# Altium schematic sheet Compareter.SchDoc(Compareter)
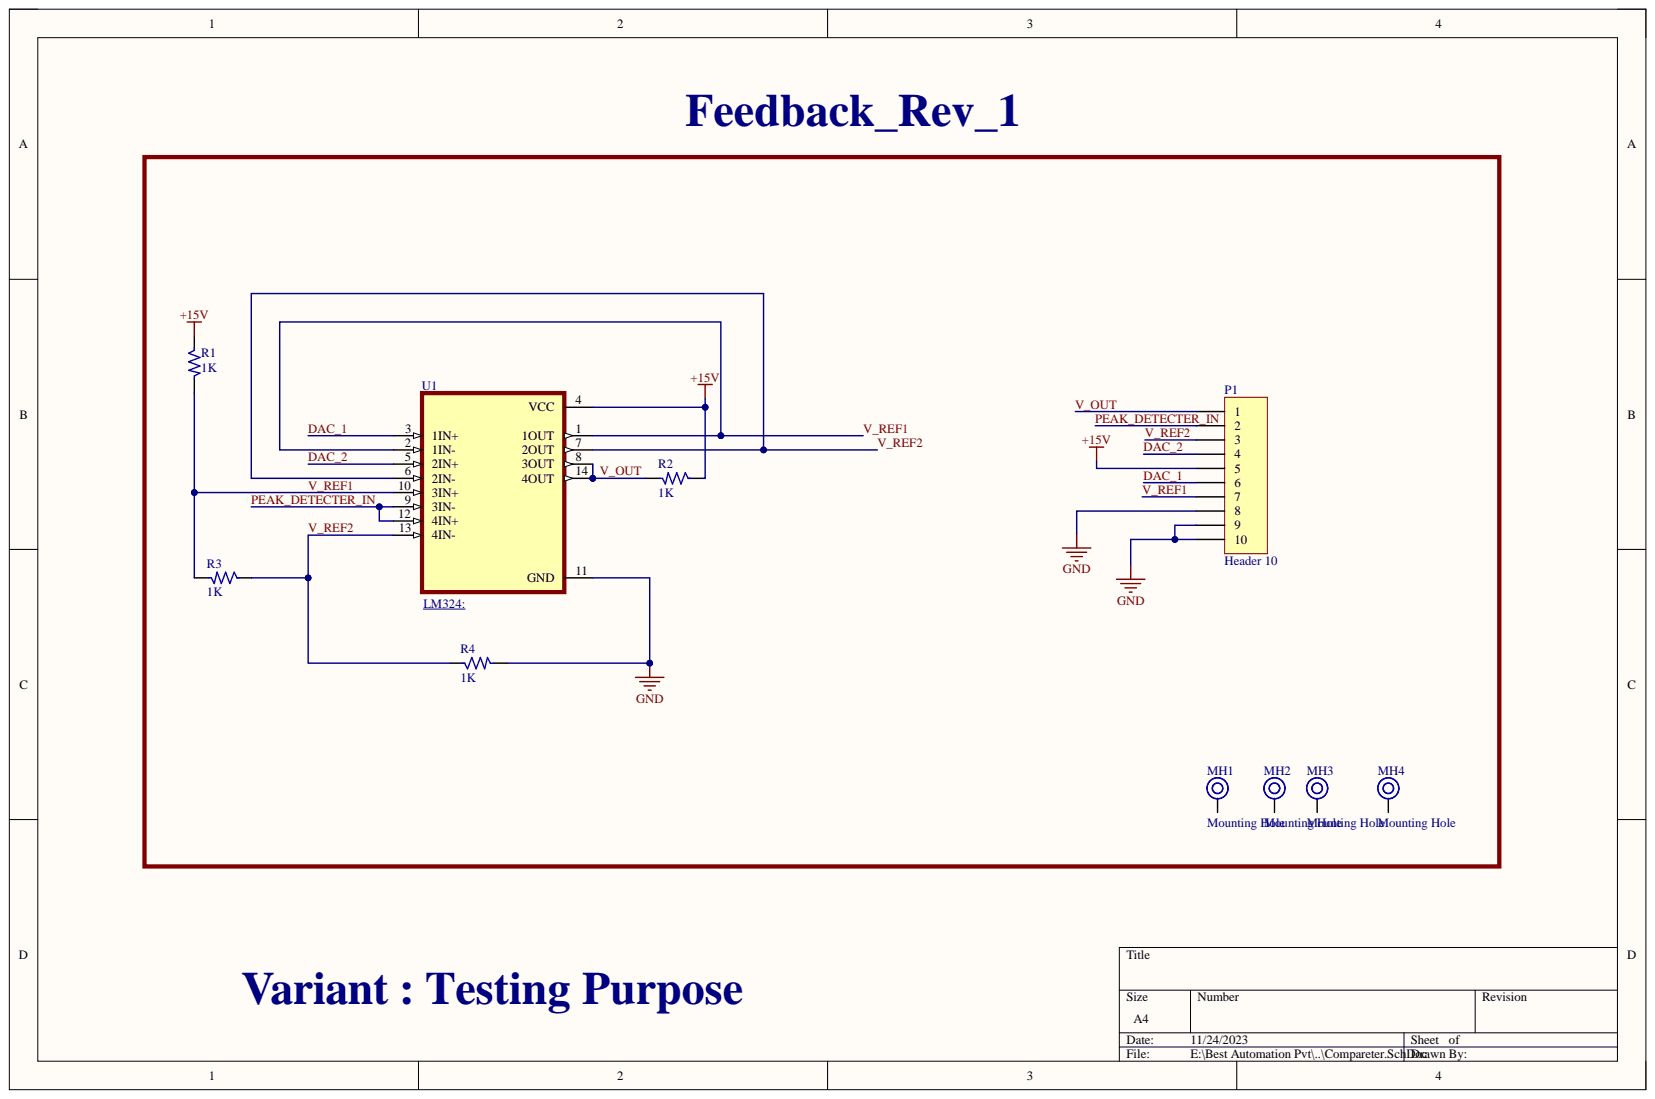
[diagram p0: Compareter.SchDoc]

## Parts
MH1  Mounting Hole  [MOUNTING]
MH2  Mounting Hole  [MOUNTING]
MH3  Mounting Hole  [MOUNTING]
MH4  Mounting Hole  [MOUNTING]
P1  Header 10  [HDR1X10]
R1  1K  [RES_753_TH_INDC]
R2  1K  [RES_753_TH_INDC]
R3  1K  [RES_753_TH_INDC]
R4  1K  [RES_753_TH_INDC]
U1  (no value)  [DIP794W45P254L1969H508Q14]

## Nets
+15V: P1.5 R1.1 R2.1 U1.4(VCC)
GND: P1.8 P1.9 P1.10 R4.2 U1.11(GND)
DAC_1: P1.6 U1.3(1IN+)
DAC_2: P1.4 U1.5(2IN+)
PEAK_DETECTER_IN: P1.2 U1.9(3IN-) U1.12(4IN+)
V_OUT: P1.1 R2.2 U1.8(3OUT) U1.14(4OUT)
V_REF1: P1.7 R1.2 R3.2 U1.1(1OUT) U1.2(1IN-) U1.10(3IN+)
V_REF2: P1.3 R3.1 R4.1 U1.6(2IN-) U1.7(2OUT) U1.13(4IN-)

Not connected: MH1 1; MH2 1; MH3 1; MH4 1

## Library data of the parts
MH1-MH4 = MH_3.5H_6D: Alternate Part Number-1: NA; Case/Package: TH; Component Kind: Standard; Description: Mounting Hole; Manufacturer: NA; Manufacturer Part Number: NA; Supplier Part Number-1: NA; Supplier-1: NA; Library Name: Best_automation_lib.SchLib
P1 = Header 10: Header, 10-Pin; Component Kind: Standard; LatestRevisionDate: 17-Jul-2002; LatestRevisionNote: Re-released for DXP Platform.; Library Name: Miscellaneous Connectors.IntLib; Signal Integrity: Connector; Publisher: Altium Limited
R1-R4 = RES_10K-0.5W-1%-TH: 10 kOhms ±1% 0.5W, 1/2W Through Hole Resistor Axial Metal Film   AXIAL; Alternate Part Number-1: NA; Manufacturer: Vishay BCcomponents; Manufacturer Part Number: SFR16S0001002FR500; Case/Package: Axial; Component Kind: Standard; ComponentLink1Description: https://datasheet.ciiva.com/28561/1911160-28561605.pdf?src-supplier=Element14; ComponentLink1URL: https://datasheet.ciiva.com/28561/1911160-28561605.pdf?src-supplier=Element14; ComponentLink2Description: https://datasheet.ciiva.com/16720/70122463-16720294.pdf?src-supplier=Allied+Electronics; ComponentLink2URL: https://datasheet.ciiva.com/16720/70122463-16720294.pdf?src-supplier=Allied+Electronics; ComponentLink3Description: https://datasheet.ciiva.com/2710/sfr16s25-2710487.pdf?src-supplier=Digi-Key; ComponentLink3URL: https://datasheet.ciiva.com/2710/sfr16s25-2710487.pdf?src-supplier=Digi-Key; ComponentLink4Description: https://datasheet.ciiva.com/2710/sfr16s25-2710488.pdf?src-supplier=Digi-Key; ComponentLink4URL: https://datasheet.ciiva.com/2710/sfr16s25-2710488.pdf?src-supplier=Digi-Key; ComponentLink5Description: https://datasheet.ciiva.com/7182/1819489-7182845.pdf?src-supplier=Newark; ComponentLink5URL: https://datasheet.ciiva.com/7182/1819489-7182845.pdf?src-supplier=Newark; ComponentLink6Description: https://datasheet.ciiva.com/16291/sfr16s25-16291262.pdf?src-supplier=Future+Electronics; ComponentLink6URL: https://datasheet.ciiva.com/16291/sfr16s25-16291262.pdf?src-supplier=Future+Electronics; ComponentLink7Description: https://datasheet.ciiva.com/3260/sfr16s25-3260959.pdf?src-supplier=Avnet; ComponentLink7URL: https://datasheet.ciiva.com/3260/sfr16s25-3260959.pdf?src-supplier=Avnet; Supplier 1: Digi-Key; Supplier Part Number-1: PPC10.0KXCT-ND; Supplier-1: SIRICOM; Library Name: Best_automation_lib.SchLib
U1 = LM324DR: 4-Channel industry standard operational amplifier 14-SOIC 0 to 70; MF: Texas Instruments; Arrow_Electronics-Purchase-URL: https://snapeda.com/shop?store=Arrow+Electronics&id=1818; Availability: Good; Component Kind: Standard; DigiKey-Purchase-URL: https://snapeda.com/shop?store=DigiKey&id=1818; Library Name: ESTEEMPCBLIB.SchLib; Mouser-Purchase-URL: https://snapeda.com/shop?store=Mouser&id=1818; MP: LM324DR; Package: SOIC-14 Texas Instruments; Price: None; SnapEDA_Link: https://www.snapeda.com/parts/LM324DR/Texas%20Instruments/view-part/1818/?ref=snap; Texas_Instruments-Purchase-URL: https://snapeda.com/shop?store=Texas+Instruments&id=1818
